# Altium schematic sheet Power2.SchDoc(Power2)
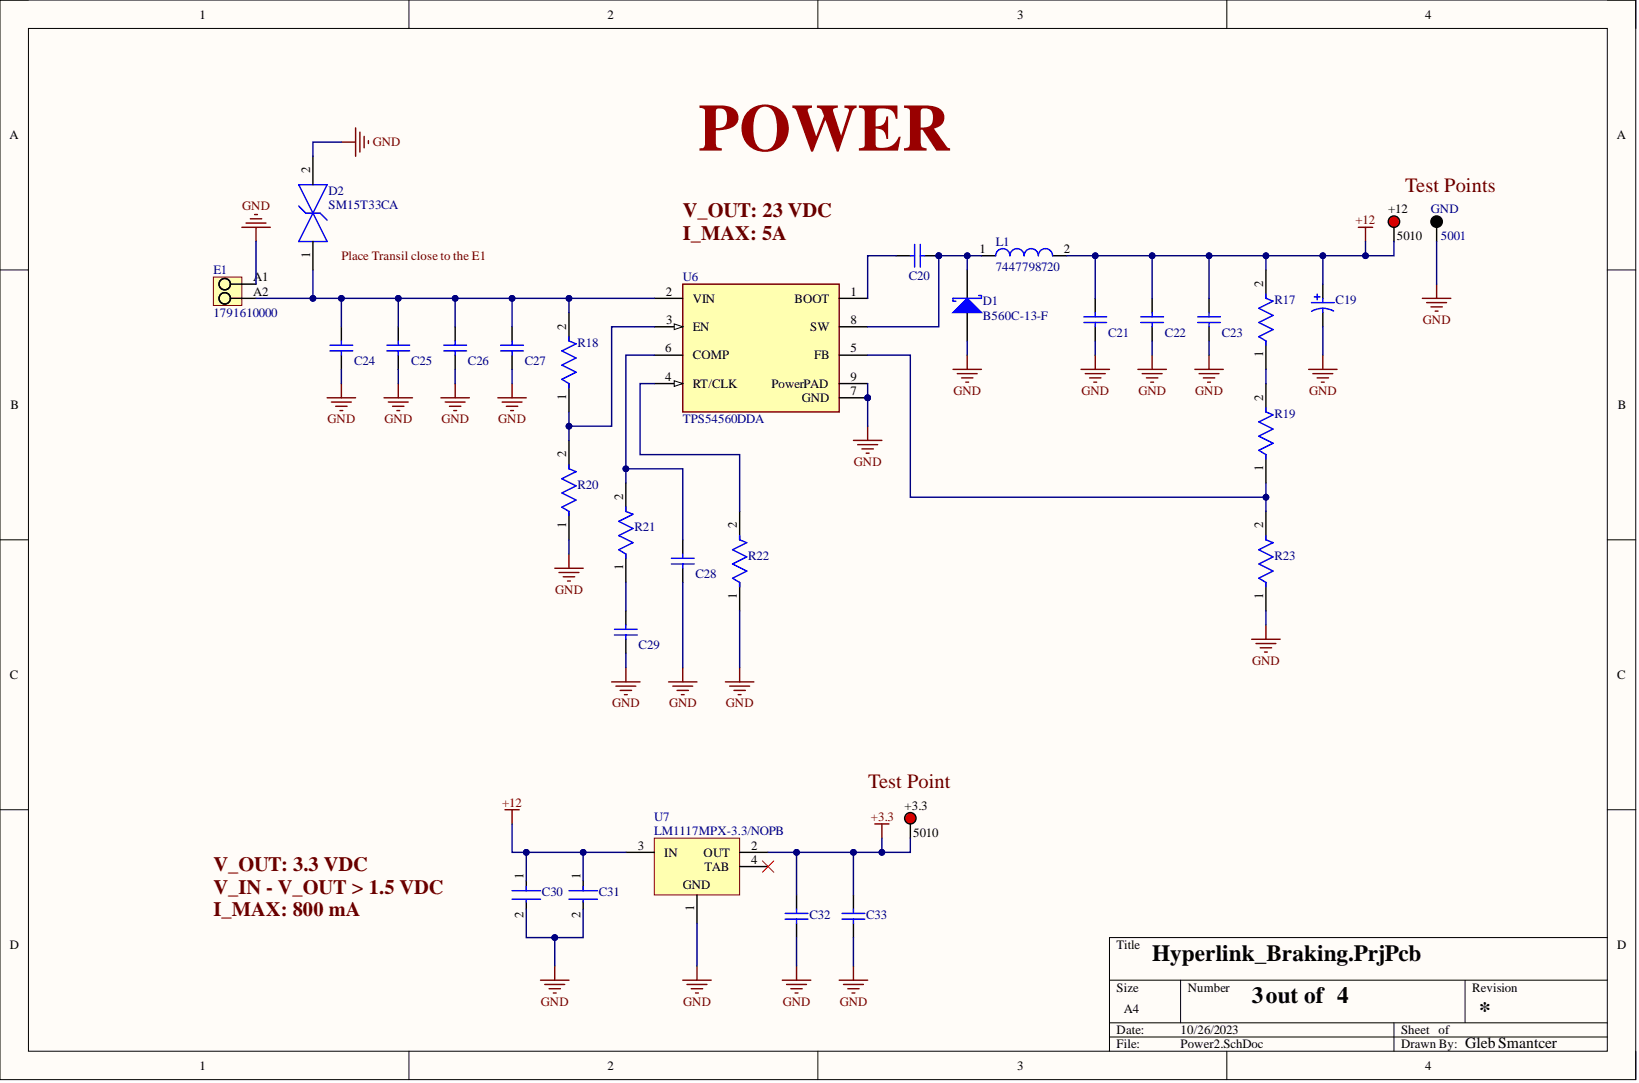
[diagram p0: Power2.SchDoc]

## Parts
+3.3  5010
+12  5010
C19  (no value)
C20  GRM188R71H104KA93D  (value not drawn)
C21  GRM32ER61C476ME15L  (value not drawn)
C22  GRM32ER61C476ME15L  (value not drawn)
C23  GRM32ER61C476ME15L  (value not drawn)
C24  GRM31CR71H225KA88L  (value not drawn)
C25  GRM31CR71H225KA88L  (value not drawn)
C26  GRM31CR71H225KA88L  (value not drawn)
C27  GRM31CR71H225KA88L  (value not drawn)
C28  GRM1885C1H470JA01D  (value not drawn)
C29  VJ0805Y472KXACW1BC  (value not drawn)
C30  C3216X5R1E476M160AC  (value not drawn)
C31  C3216X5R1E476M160AC  (value not drawn)
C32  GRM188R71H104KA93D  (value not drawn)
C33  GRM188R71H104KA93D  (value not drawn)
D1  B560C-13-F
D2  SM15T33CA
E1  1791610000
GND  5001
L1  7447798720
R17  CRCW06030000Z0EB  (value not drawn)
R18  CRCW0402442KFKED  (value not drawn)
R19  CRCW060310K2FKEA  (value not drawn)
R20  CRCW040290K9FKED  (value not drawn)
R21  CRCW060316K9FKEA  (value not drawn)
R22  CRCW0805243KFKEA  (value not drawn)
R23  CRCW0402143KFKED  (value not drawn)
U6  TPS54560DDA
U7  LM1117MPX-3.3/NOPB

## Nets
+3.3: +3.3.1 C32.2 C33.2 U7.2(OUT)
+12: +12.1 C19.1 C21.2 C22.2 C23.2 C30.1 C31.1 L1.2 R17.2 U7.3(IN)
GND: C19.2 C21.1 C22.1 C23.1 C24.1 C25.1 C26.1 C27.1 C28.1 C29.1 C30.2 C31.2 C32.1 C33.1 D1.2 D2.2 E1.A1 GND.1 R20.1 R22.1 R23.1 U6.7(GND) U6.9(PowerPAD) U7.1
NetC20_1: C20.1 D1.1 L1.1 U6.8(SW)
NetC20_2: C20.2 U6.1(BOOT)
NetC24_2: C24.2 C25.2 C26.2 C27.2 D2.1 E1.A2 R18.2 U6.2(VIN)
NetC28_2: C28.2 R21.2 U6.6(COMP)
NetC29_2: C29.2 R21.1
NetR17_1: R17.1 R19.2
NetR18_1: R18.1 R20.2 U6.3(EN)
NetR19_1: R19.1 R23.2 U6.5(FB)
NetR22_2: R22.2 U6.4(RT/CLK)

Not connected: U7 4(TAB)

## Library data of the parts
+3.3 +12 = CMP-1672-00002-4: Test Point, Red, Through Hole, RoHS, Bulk; Manufacturer: Keystone; Colour: Red; Component Kind: Standard; ComponentLink1Description: Manufacturer URL; ComponentLink1URL: http://www.keyelco.com/; ComponentLink2Description: Datasheet; ComponentLink2URL: http://www.keyelco.com/product-pdf.cfm?p=1319; Contact Material: Bronze; Contact Plating: Silver; DatasheetVersion: Rev. F, 12/2013; Height: 8.12 mm; JLC: C238126; Library Name: Altium Content Vault; Mount: Through Hole; Mounting Technology: Through Hole; Package Quantity: 100; PackageDescription: 1-Pin Through Hole Device, Dia 3.17 mm; PackageReference: 5010; Packaging: Bulk; Pins: 1; REACH SVHC: No SVHC; RoHSCompliant: true
C19 = CMP-2000-06209-1: ALUMINUM ELECTROLYTIC CAPACITORS - SMD 100UF ±20% 16V SMD,6.3X5.3MM ROHS,  C134723; Manufacturer: Panasonic; Capacitance: 22µF; Impedance: 0.88O; Lifetime@Temperature: 2000Hrs@105°C; Rated Voltage: 50V; Ripple Current: 165mA; Type: Can; Case Size/Dimension: Ø6.3x5.8mm; Component Kind: Standard; Datasheet URL: http://www.mouser.com/ds/2/315/ABA0000CE120-68386.pdf; Datasheet Version: 03/2014; JLC: C249982; Library Name: Altium Content Vault; Manufacturer URL: http://www.panasonic.com/; Mounting Technology: SurfaceMount; Operating Temp Range: -55to+105°C; Operating Temp-Max: 105°C; Package Description: 2-Pin Aluminum Electrolytic Capacitor, Base 6.6 x 6.6 mm, Height 6.10 mm; Package Reference: EEE-FK1H220P; Series: FK
C20 C32 C33 = CMP-2100-03643-1: Chip Capacitor, 0.1 uF, +/- 10%, 50 V, -55 to 125 degC, 0603 (1608 Metric), RoHS, Tape and Reel; Manufacturer: Murata; Capacitance: 100nF; Dielectric: X7R; Dielectric Material: Ceramic; Insulation Resistance: 10GR; Voltage: 50V; Case Code (Imperial): 0603; Case Code (Metric): 1608; Case/Package: 0603; Component Kind: Standard; Contact Plating: Tin; Datasheet URL: http://psearch.en.murata.com/capacitor/product/GRM188R71H104KA93%23.pdf; Datasheet Version: 42675.0; Depth: 0.8mm; Height: 0.8mm; JLC: C14663; Lead Free: LeadFree; Length: 1.6mm; Library Name: Altium Content Vault; Manufacturer URL: http://www.murata.com/; Material: Ceramic; Max Operating Temperature: 125degC; Min Operating Temperature: -55degC; Mount: SurfaceMount; Number of Pins: 2; Package Description: 2-Pin Surface Mount Device, Body 1.6 x 0.8 mm; Package Quantity: 1; Package Reference: 0603; Packaging: TapeandReel; Radiation Hardening: No; REACH SVHC: NoSVHC; RoHSCompliant: true; Termination: SMD/SMT; Thickness: 0.035inch; Tolerance: 10%; Voltage Rating: 50V; Voltage Rating (DC): 50V; Width: 0.8mm
C21-C23 = CMP-2000-05260-1: CAP, Ceramic, 47 uF, 20%, 16 V, 1210, RoHS, Tape and Reel; Manufacturer: Murata; Brand: MurataElectronics; Capacitance: 47uF; Case Height: 2.5mm; Case Length: 3.2mm; Dielectric: X5R; Type: GeneralPurposeMLCC; Voltage Rating DC: 16V; Case Code - in: 1210; Case Code - mm: 3225; Case Width: 2.5mm; Component Kind: Standard; Datasheet URL: https://datasheet.ciiva.com/3777/2723grm32er61c476me1523-3777296.pdf; Datasheet Version: Aug-14; Factory Pack Quantity: 1000; JLC: C730501; Library Name: Altium Content Vault; Manufacturer URL: http://www.murata.com/; Maximum Operating Temperature: +85C; Minimum Operating Temperature: -55C; Package / Case: 1210(3225metric); Package Description: 2-Pin Surface Mount Device, Body 3.2 x 2.5 mm; Package Reference: 1210; Packaging: Reel; Product: GeneralTypeMLCCs; Product Category: MultilayerCeramicCapacitorsMLCC-SMD/SMT; RoHS: Details; Series: GRM; Termination Style: SMD/SMT; Tolerance: 20%
C24-C27 = CMP-2000-05257-1: CAP, Ceramic, 2.2 uF, 10%, 50 V, 1206, RoHS, Tape and Reel; Manufacturer: Murata; Capacitance: 2.2µF; Catalog Drawings: GRMSeries_1206_1.6; Featured Product: GA3SafetyRecognizedCapsandHi-CapMLCC; Online Catalog: GRMSeries; Other Names: 490-3367-6; Product Training Modules: High-CapMultilayerCeramicCapacitors; Temperature Coefficient: X7R; Voltage - Rated: 50V; Applications: GeneralPurpose; Category: Capacitors; Component Kind: Standard; Datasheet URL: https://datasheet.ciiva.com/29199/454960-da-01-en-vs-kondensator-1206-29199432.pdf; Datasheet Version: Dec-10; Family: CeramicCapacitors; Features: -; Height - Seated (Max): -; JLC: C50254; Lead Spacing: -; Lead Style: -; Library Name: Altium Content Vault; Manufacturer URL: http://www.murata.com/; Mounting Type: SurfaceMount,MLCC; Operating Temperature: -55degC~125degC; Package / Case: 1206(3216Metric); Packaging: Digi-Reel; Ratings: -; Series: GRM; Size / Dimension: 0.126"Lx0.063"W(3.20mmx1.60mm); Standard Package: 1; Thickness (Max): 0.071"(1.80mm); Tolerance: ±10%
C28 = CMP-2006-02672-1: Manufacturer: Murata; Component Kind: Standard; JLC: C1671; Library Name: Altium Content Vault; Part Number: GRM1885C1H470JA01D
C29 = CMP-2007-03450-1: Manufacturer: Vitramon; Component Kind: Standard; JLC: C1779; Library Name: Altium Content Vault; Part Number: VJ0805Y472KXACW1BC
C30 C31 = CMP-2000-05397-2: CAP CER 47UF 25V X5R 1206; 3DS Part Number: 220-00004; Manufacturer: TDK; Material: Ceramic; Temperature Coefficient: 15%; Tolerance: 20%; Automotive: No; Capacitance: 47uF; Category: Cap; Component Kind: Standard; ComponentLink2Description: Datasheet; ComponentLink2URL: https://product.tdk.com/info/en/catalog/datasheets/mlcc_commercial_general_en.pdf; Device Class L1: Passive Components; Device Class L2: Capacitors; Device Class L3: Ceramic Capacitors; Digikey Description: CAP CER 47UF 25V X5R 1206; Footprint Url: https://product.tdk.com/info/en/catalog/spec/mlccspec_commercial_general_midvoltage_en.pdf; Height: 1.8mm; Ipc Land Pattern Name: CAPC320160X160; JLC: C607993; Lead Free: Yes; Library Name: Altium Content Vault; Manufacturer Part Number: C3216X5R1E476M160AC; Mouser Description: Multilayer Ceramic Capacitors MLCC - SMD/SMT 1206 47uF 25volts X5R 20%; Octopart: https://octopart.com/c3216x5r1e476m160ac-tdk-25947783; Package: C3216-160; RoHS: TRUE; Temperature: -55°C to +85°C; Temperature Characteristic: X5R; Temperature Range High: +85°C; Temperature Range Low: -55°C; Value: 47uF; Voltage: 25V; Voltage Rating: 25V
D1 = CMP-2000-05098-2: Schottky Barrier Rectifier, 5 A, 60 V, -55 to 125 degC, 2-Pin SMD, RoHS, Tape and Reel; Brand: DiodesIncorporated; Configuration: Single; If - Forward Current: 5A; Ifsm - Forward Surge Current: 100A; Ir - Reverse Current: 500uA; Unit Weight: 0.007408oz; Vf - Forward Voltage: 0.7V; Vrrm - Repetitive Reverse Voltage: 60V; Component Kind: Standard; Datasheet URL: https://datasheet.ciiva.com/27052/1538961-27052355.pdf; Datasheet Version: Rev. 7-2, 06/2007; Factory Pack Quantity: 3000; Height: 2.5mm; JLC: C85100; Length: 7.11mm; Library Name: Altium Content Vault; Manufacturer: DiodesIncorporated; Manufacturer URL: http://www.diodes.com/; Maximum Operating Temperature: +125C; Minimum Operating Temperature: -55C; Mounting Style: SMD/SMT; Operating Temperature Range: -55Cto+125C; Package / Case: SMC; Packaging: Reel; Product: SchottkyDiodes; Product Category: SchottkyDiodes&Rectifiers; RoHS: True; Series: B560; Technology: Si; Termination Style: SMD/SMT; Type: SchottkyBarrierRectifier; Width: 6.22mm
D2 = CMP-12225-000046-1: TVS DIODE 28.2V 59V SMC; Manufacturer: STMicroelectronics; Manufacturer Part Number: SM15T33CAY; Automotive Grade: Grade 0; Breakdown Voltage: 31.4V; Clamping Voltage: 45.7V; Direction: Bidirectional; Max Junction Temp: +150°C; Number Of Channels: 1; Peak Pulse Current: 33A; Peak Pulse Power: 1500W; Standoff Height: 0.05mm; Automotive: Yes; Category: Diode; Component Kind: Standard; ComponentLink2Description: Datasheet; ComponentLink2URL: https://www.st.com/resource/en/datasheet/sm15ty.pdf; Device Class L1: Circuit Protection; Device Class L2: TVS Diodes; Device Class L3: unset; Digikey Description: TVS DIODE 28.2V 59V SMC; Height: 2.65mm; JLC: C133707; Lead Free: Yes; Library Name: Altium Content Vault; Mouser Description: TVS Diodes - Transient Voltage Suppressors 1500 W 10kW Transil 5.8V tp 70V BI; Octopart: https://octopart.com/sm15t33cay-stmicroelectronics-19039450; Package: SMC-CA; Reverse Standoff Voltage: 28.2V; RoHS: TRUE; Temperature: -40°C to +150°C; Temperature Range High: +150°C; Temperature Range Low: -40°C
E1 = CMP-1559-01622-1: OMNIMATE Signal - series PM; Manufacturer: Weidmueller; Contact_material: Cu-Leg; Contact_surface: tinned; Insulating_material: Wemid (PA); Mounting_onto_the_PCB: Solder connection; No_of_poles: 2; Pitch_inch: 0,197 inch; Pitch_mm: 5 mm; Rated_current_use_group_B_UL_1059: 15 A; Rated_voltage_for_surge_voltage_class_pollution_degree_II_2: 600 V; Rated_voltage_use_group_B_UL_1059: 300 V; Short_text_for_material: PM 5.00/02/90 3.5SN OR BX; Side_termination_characteristic: closed side; Solder_pin_length_mm: 3,5 mm; Solid_max_H05_07_V_U: 2,5 mm²; Solid_min_H05_07_V_U: 0,13 mm²; UL_94_flammability_rating: V-0; Wire_cross_section_AWG_max_UL_1059: AWG 14; Wire_cross_section_AWG_min_UL_1059: AWG 26; Colour: Orange; Component Kind: Standard; ComponentLink1Description: Manufacturer URL; ComponentLink1URL: http://www.weidmueller.com/; ComponentLink2Description: URL Link1; ComponentLink2URL: http://catalog.weidmueller.com/catalog/Start.do?localeId=en&ObjectID=1791610000; JLC: C500037; Library Name: Altium Content Vault; PackageReference: PM_5_00_90_02; Packaging: Box; PartNumber: 1791610000; Qty: 500 PCS; Revision: V1
GND = CMP-2000-05181-1: Test Point, Black, 1-Pin THD, RoHS, Bulk; Manufacturer: Keystone; Alternate Color: 5004K-ND  -TESTPOINTPCMINI.040"DYELLOW  5003K-ND  -TESTPOINTPCMINI.040"DORANGE  5002K-ND  -TESTPOINTPCMINI.040"DWHITE  5000K-ND  -TESTPOINTPCMINI.040"DRED; Catalog Drawings: 5000Series; Kits: DKTGRS232C-KIT-ND  -TTLCONVERTER; Online Catalog: ColorCodedTestPoints; Other Names: 5001K; Category: TestandMeasurement; Color: Black; Component Kind: Standard; Datasheet URL: https://ciiva.com/datasheet-click-2917627?src=ciiva&sig=bd195616; Datasheet Version: Rev. G, 12/2008; Family: TestPoints; JLC: C238122; Library Name: Altium Content Vault; Manufacturer URL: http://www.keyelco.com/; Material: Base,Nylon46,UL94V-0; Mounting Type: 0.040"(1.02mm)HoleDiameter; Packaging: Bulk; RoHS Information: RoHSComplianceStatement; Series: THM; Standard Package: 100; Terminal: 0.010"x0.020"(0.25mmx0.51mm)PhosphorBronzeWire,SilverPlated; Type: PCTestPoint,Miniature
L1 = CMP-0227-00050-1: SMD Power Inductor WE-PDF, L = 7.20 µH; Inductance: 7.20 ÂµH; Component Kind: Standard; ComponentLink1Description: Manufacturer Link; ComponentLink1URL: http://www.we-online.com; ComponentLink2Description: Datasheet; ComponentLink2URL: http://www.we-online.de/katalog/media/pdf/7447798720.pdf; DatasheetVersion: ver 1, Dec-2009; Library Name: Altium Content Vault; PackageDescription: SMD Inductor, 2-Leads, Body 10.2 x 10.2 mm; PackageReference: WE-PDF-1064; PackageVersion: 2009
R17 = CMP-2000-03818-2: RES Thick Film, 0Ω, 0.1W, 0603; Max Power Dissipation: 100mW; Resistance: 0mR; Temperature Coefficient: 100ppm/°C; Tolerance: 1%; Voltage Rating: 75V; Case Code (Imperial): 0603; Case Code (Metric): 1608; Case/Package: 0603; Component Kind: Standard; Composition: Thick Film; Contact Plating: Tin; Depth: 0.85mm; Features: Automotive AEC-Q200; Height: 0.45mm; JLC: C21189; Lead Free: Lead Free; Length: 1.55mm; Library Name: Altium Content Vault; Max Operating Temperature: 155°C; Military Standard: Not; Min Operating Temperature: -55°C; Number of Pins: 2; Number of Terminations: 2; Package Quantity: 10000; Packaging: Tape and Reel; Power Rating: 100mW; Radiation Hardening: No; Termination: SMD/SMT; Voltage Rating (DC): 75V; Weight: 7.1E-05oz; Width: 0.033inch
R18 = CMP-2002-00008-2: RES Thick Film, 442kΩ, 1%, 0.063W, 100ppm/°C, 0402; Max Power Dissipation: 63mW; Resistance: 442kR; Voltage Rating: 50V; Case Code (Imperial): 0402; Case Code (Metric): 1005; Case/Package: 0402; Component Kind: Standard; Composition: Thick Film; Contact Plating: Tin; Depth: 0.5mm; Features: Automotive AEC-Q200; Height: 0.35mm; JLC: C5101; Length: 1mm; Library Name: Altium Content Vault; Max Operating Temperature: 155°C; Military Standard: Not; Min Operating Temperature: -55°C; Number of Terminations: 2; Package Quantity: 10; Packaging: Tape and Reel; Power Rating: 63mW; Radiation Hardening: No; Temperature Coefficient: 100ppm/°C; Termination: SMD/SMT; Tolerance: 1%; Weight: 2.3E-05oz; Width: 0.02inch; Working Voltage: 50V
R19 = CMP-2000-03840-2: RES Thick Film, 10.2kΩ, 1%, 0.1W, 100ppm/°C, 0603; Max Power Dissipation: 100mW; Resistance: 10.2kR; Voltage Rating: 75V; Case Code (Imperial): 0603; Case Code (Metric): 1608; Case/Package: 0603; Component Kind: Standard; Composition: Thick Film; Contact Plating: Tin; Depth: 0.85mm; Features: Automotive AEC-Q200; Height: 0.45mm; JLC: C22772; Lead Free: Lead Free; Length: 1.55mm; Library Name: Altium Content Vault; Max Operating Temperature: 155°C; Military Standard: Not; Min Operating Temperature: -55°C; Number of Terminations: 2; Package Quantity: 10; Packaging: Tape and Reel; Power Rating: 100mW; Radiation Hardening: No; Temperature Coefficient: 100ppm/°C; Termination: SMD/SMT; Tolerance: 1%; Voltage Rating (DC): 75V; Weight: 7.1E-05oz; Width: 0.033inch
R20 = CMP-2002-00265-2: RES Thick Film, 90.9kΩ, 1%, 0.063W, 100ppm/°C, 0402; Max Power Dissipation: 63mW; Resistance: 90.9kR; Voltage Rating: 50V; Case Code (Imperial): 0402; Case Code (Metric): 1005; Case/Package: 0402; Component Kind: Standard; Composition: Thick Film; Contact Plating: Tin; Depth: 0.5mm; Features: Automotive AEC-Q200; Height: 0.35mm; JLC: C26989; Lead Free: Lead Free; Length: 1mm; Library Name: Altium Content Vault; Max Operating Temperature: 155°C; Military Standard: Not; Min Operating Temperature: -55°C; Mount: Surface Mount; Number of Pins: 2; Number of Terminations: 2; Package Quantity: 10; Packaging: Tape and Reel; Power Rating: 63mW; Radiation Hardening: No; Temperature Coefficient: 100ppm/°C; Termination: SMD/SMT; Tolerance: 1%; Voltage Rating (DC): 50V; Weight: 2.3E-05oz; Width: 0.02inch
R21 = CMP-2000-02941-2: RES Thick Film, 16.9kΩ, 1%, 0.1W, 100ppm/°C, 0603; Max Power Dissipation: 100mW; Resistance: 16.9kR; Voltage Rating: 75V; Case Code (Imperial): 0603; Case Code (Metric): 1608; Case/Package: 0603; Component Kind: Standard; Composition: Thick Film; Contact Plating: Tin; Depth: 0.85mm; Features: Automotive AEC-Q200; Height: 0.45mm; JLC: C25954; Lead Free: Lead Free; Length: 1.55mm; Library Name: Altium Content Vault; Max Operating Temperature: 155°C; Military Standard: Not; Min Operating Temperature: -55°C; Mount: Surface Mount; Number of Pins: 2; Number of Terminations: 2; Package Quantity: 10; Packaging: Tape and Reel; Power Rating: 100mW; Radiation Hardening: No; Temperature Coefficient: 100ppm/°C; Termination: SMD/SMT; Tolerance: 1%; Voltage Rating (DC): 75V; Weight: 7.1E-05oz; Width: 0.033inch; Working Voltage: 75V
R22 = CMP-2001-03707-2: RES Thick Film, 243kΩ, 1%, 0.125W, 100ppm/°C, 0805; Max Power Dissipation: 125mW; Resistance: 243kR; Voltage Rating: 150V; Case Code (Imperial): 0805; Case Code (Metric): 2012; Case/Package: 0805; Component Kind: Standard; Composition: Thick Film; Contact Plating: Tin; Depth: 1.25mm; Features: Automotive AEC-Q200; Height: 0.02inch; JLC: C17573; Lead Free: Lead Free; Length: 2mm; Library Name: Altium Content Vault; Max Operating Temperature: 155°C; Military Standard: Not; Min Operating Temperature: -55°C; Number of Pins: 2; Number of Terminations: 2; Package Quantity: 10; Packaging: Tape and Reel; Power Rating: 125mW; Radiation Hardening: No; Temperature Coefficient: 100ppm/°C; Termination: SMD/SMT; Tolerance: 1%; Voltage Rating (DC): 150V; Weight: 0.000194oz; Width: 0.049inch
R23 = CMP-2002-08203-2: RES Thick Film, 143kΩ, 1%, 0.063W, 100ppm/°C, 0402; Power Rating: 63mW; Resistance: 143kR; Voltage Rating: 50V; Case Code (Imperial): 0402; Case Code (Metric): 1005; Case/Package: 0402; Component Kind: Standard; Composition: Thick Film; Contact Plating: Tin; Depth: 0.5mm; Height: 0.35mm; JLC: C82292; Lead Free: Lead Free; Length: 1mm; Library Name: Altium Content Vault; Max Operating Temperature: 155°C; Military Standard: Not; Min Operating Temperature: -55°C; Number of Pins: 2; Package Quantity: 10000; Packaging: Tape and Reel; Radiation Hardening: No; Temperature Coefficient: 100ppm/°C; Termination: SMD/SMT; Tolerance: 1%; Voltage Rating (DC): 50V; Weight: 2.3E-05oz; Width: 0.5mm
U6 = CMP-04918-000033-1: IC REG BCK SPLIT RAIL ADJ 8SOPWR; Manufacturer: Texas Instruments; Max Duty Cycle: 100%; Max Junction Temp: +150°C; Max Supply Voltage: 60V; Min Supply Voltage: 4.5V; Nominal Supply Current: 146uA; Number Of Outputs: 1; Output Current: 5A; Output Type: AdjustableProgrammable; Output Voltage: 0.8-58.8V; Standoff Height: 0mm; Switching Frequency: 100-2500kHz; Switching Topology: Buck; Automotive: No; Category: IC; Component Kind: Standard; ComponentLink2Description: Datasheet; ComponentLink2URL: http://www.ti.com/lit/ds/symlink/tps54560.pdf; Device Class L1: Integrated Circuits (ICs); Device Class L2: Power Management ICs; Device Class L3: Voltage Regulators - Switching; Digikey Description: IC REG BCK SPLIT RAIL ADJ 8SOPWR; Height: 1.7mm; Ipc Land Pattern Name: SOIC127P600X170-8; JLC: C31966; Lead Free: Yes; Library Name: Altium Content Vault; Manufacturer Part Number: TPS54560DDA; Mouser Description: Voltage Regulators - Switching Regulators 60V Input 5 A SD DC-DC Cnvtr; Octopart: https://octopart.com/tps54560dda-texas+instruments-26977150; Package: DDA0008E; RoHS: TRUE; Temperature: -40°C to +125°C; Temperature Range High: +125°C; Temperature Range Low: -40°C
U7 = CMP-0062-01639-4: 800mA Low-Dropout Linear Regulator, 4-pin SOT-223, Pb-Free; Manufacturer: Texas Instruments; CompType: LDO IC; High-Reliability: Y; PackageTopMarking: XYTT*N05A; PowerWise: N; Code_IPC: SOT223-4; Code_JEDEC: TO-261-AA; Component Kind: Standard; ComponentLink1Description: Datasheet URL; ComponentLink1URL: http://www.ti.com/general/docs/lit/getliterature.tsp?genericPartNumber=LM1117-N&fileType=pdf; JLC: C9662; Library Name: Altium Content Vault; PackageDescription: Molded SOT-223, 6.50 x 3.56 x 1.6mm Body, 4 Lead, 2.3mm Pitch; PackageReference: DCY0004A; PartNumber: LM1117MPX-3.3/NOPB; PinCount: 4; RoHS: Y
